# Altium schematic sheet MainCircuit.SchDoc
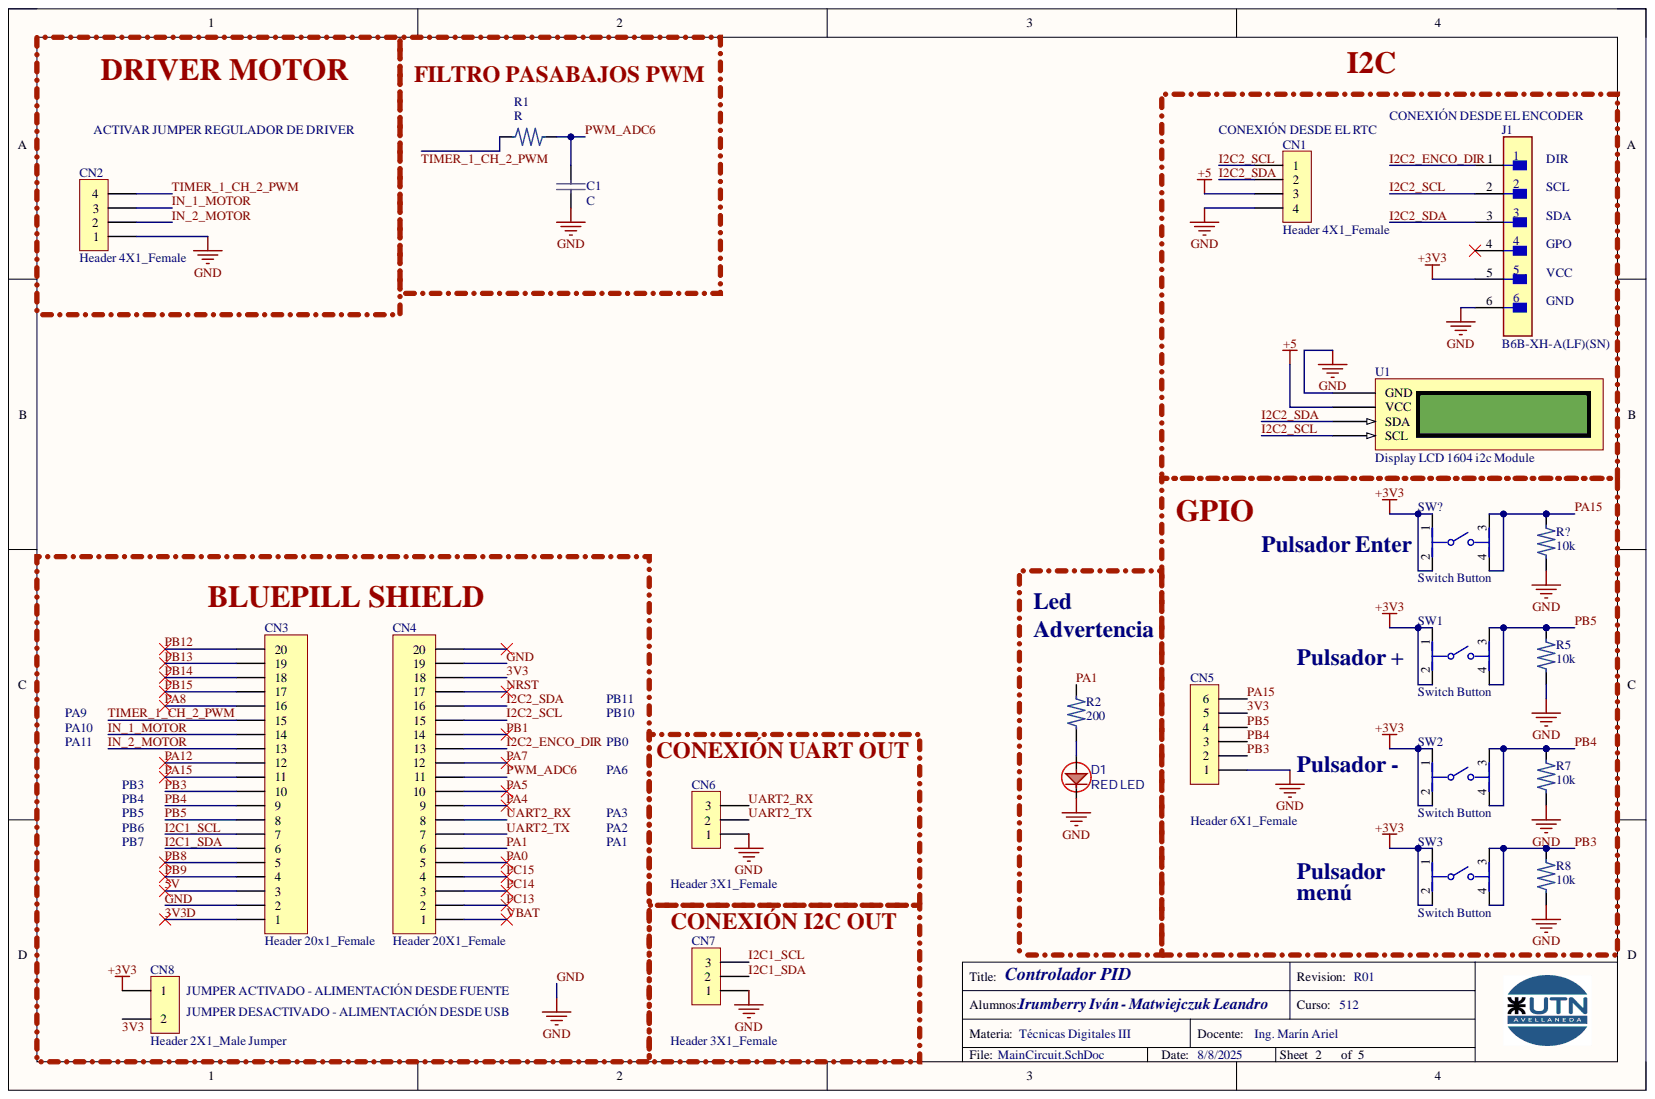
[diagram p0: MainCircuit.SchDoc]

## Parts
C1  C  [CAP_Axial_0.2mm]
CN1  Header 4X1_Female  [1*4P_FEMALE]
CN2  Header 4X1_Female  [1*4P_FEMALE]
CN3  Header 20x1_Female  [1*20P_FEMALE]
CN4  Header 20X1_Female  [1*20P_FEMALE]
CN5  Header 6X1_Female  [1*6P_FEMALE]
CN6  Header 3X1_Female  [1*3P_FEMALE]
CN7  Header 3X1_Female  [1*3P_FEMALE]
CN8  Header 2X1_Male Jumper  [JUMPER 2x1 PIN]
D1  RED LED  [LED_DIODE_3.9mm]
J1  B6B-XH-A(LF)(SN)  [JST 6 PIN CONNECTOR]
R1  R  [RES_AXIAL_3.2_6MM]
R2  200  [RES_AXIAL_3.2_6MM]
U1  Display LCD 1604 i2c Module  [1*4P_FEMALE]

## Nets
3V3: CN4.18 CN5.5 CN8.1 CN8.2 J1.5
3V3D: CN3.1
5V: CN1.3 CN3.3 U1.2(VCC)
GND: C1.2 CN1.4 CN2.1 CN3.2 CN4.19 CN5.1 CN6.1 CN7.1 D1.K J1.6 U1.1(GND)
I2C1_SCL: CN3.7 CN7.3
I2C1_SDA: CN3.6 CN7.2
I2C2_ENCO_DIR: CN4.13 J1.1
I2C2_SCL: CN1.1 CN4.15 J1.2 U1.4(SCL)
I2C2_SDA: CN1.2 CN4.16 J1.3 U1.3(SDA)
IN_1_MOTOR: CN2.3 CN3.14
IN_2_MOTOR: CN2.2 CN3.13
NRST: CN4.17
PA0: CN4.5
PA1: CN4.6 R2.2
PA4: CN4.9
PA5: CN4.10
PA7: CN4.12
PA8: CN3.16
PA12: CN3.12
PA15: CN3.11 CN5.6
PB1: CN4.14
PB3: CN3.10 CN5.2
PB4: CN3.9 CN5.3
PB5: CN3.8 CN5.4
PB8: CN3.5
PB9: CN3.4
PB12: CN3.20
PB13: CN3.19
PB14: CN3.18
PB15: CN3.17
PC13: CN4.2
PC14: CN4.3
PC15: CN4.4
PWM_ADC6: C1.1 CN4.11 R1.2
TIMER_1_CH_2_PWM: CN2.4 CN3.15 R1.1
UART2_RX: CN4.8 CN6.3
UART2_TX: CN4.7 CN6.2
VBAT: CN4.1
NetD1_A: D1.A R2.1

Not connected: CN4 20; J1 4

## Library data of the parts
C1 = CAP_NP: Non-Polarized Capacitor; Component Kind: Standard; Library Name: ProyectoTD3.SchLib
CN1 CN2 = Header 4X1_Female: Header, 4-Pin, Single row,female; Component Kind: Standard; LatestRevisionDate: 17-Jul-2002; LatestRevisionNote: Re-released for DXP Platform.; Library Name: ProyectoTD3.SchLib; Publisher: Altium Limited
CN3 CN4 = Header 20x1_Female: Header, 16-Pin, Single row,female; Component Kind: Standard; Library Name: ProyectoTD3.SchLib
CN5 = Header 6X1_Female: Header, 6-Pin, Single row,female; Component Kind: Standard; LatestRevisionDate: 17-Jul-2002; LatestRevisionNote: Re-released for DXP Platform.; Library Name: ProyectoTD3.SchLib; Publisher: Altium Limited
CN6 CN7 = Header 3X1_Female: Header, 3-Pin, Single row,female; Component Kind: Standard; LatestRevisionDate: 17-Jul-2002; LatestRevisionNote: Re-released for DXP Platform.; Library Name: ProyectoTD3.SchLib; Publisher: Altium Limited
CN8 = Jumper 2 Pin: Header, 2-Pin, Single row,male; Component Kind: Standard; LatestRevisionDate: 17-Jul-2002; LatestRevisionNote: Re-released for DXP Platform.; Library Name: ProyectoTD3.SchLib; Publisher: Altium Limited
D1 = LED: Component Kind: Standard; Description: LED; Library Name: ProyectoSchlib1.SchLib
J1 = JST 6 PIN: CONN HEADER VERT 6POS 2.5MM; Component Kind: Standard; Library Name: ProyectoTD3.SchLib
R1 R2 = RES: Through-hole Resistor; Component Kind: Standard; Library Name: ProyectoTD3.SchLib
U1 = Display LCD 1604 i2c Module: Component Kind: Standard; Description: Display LCD 1604 i2c Module; Library Name: ProyectoTD3.SchLib
